# Altium schematic sheet Sheet5.SchDoc(Sheet5)
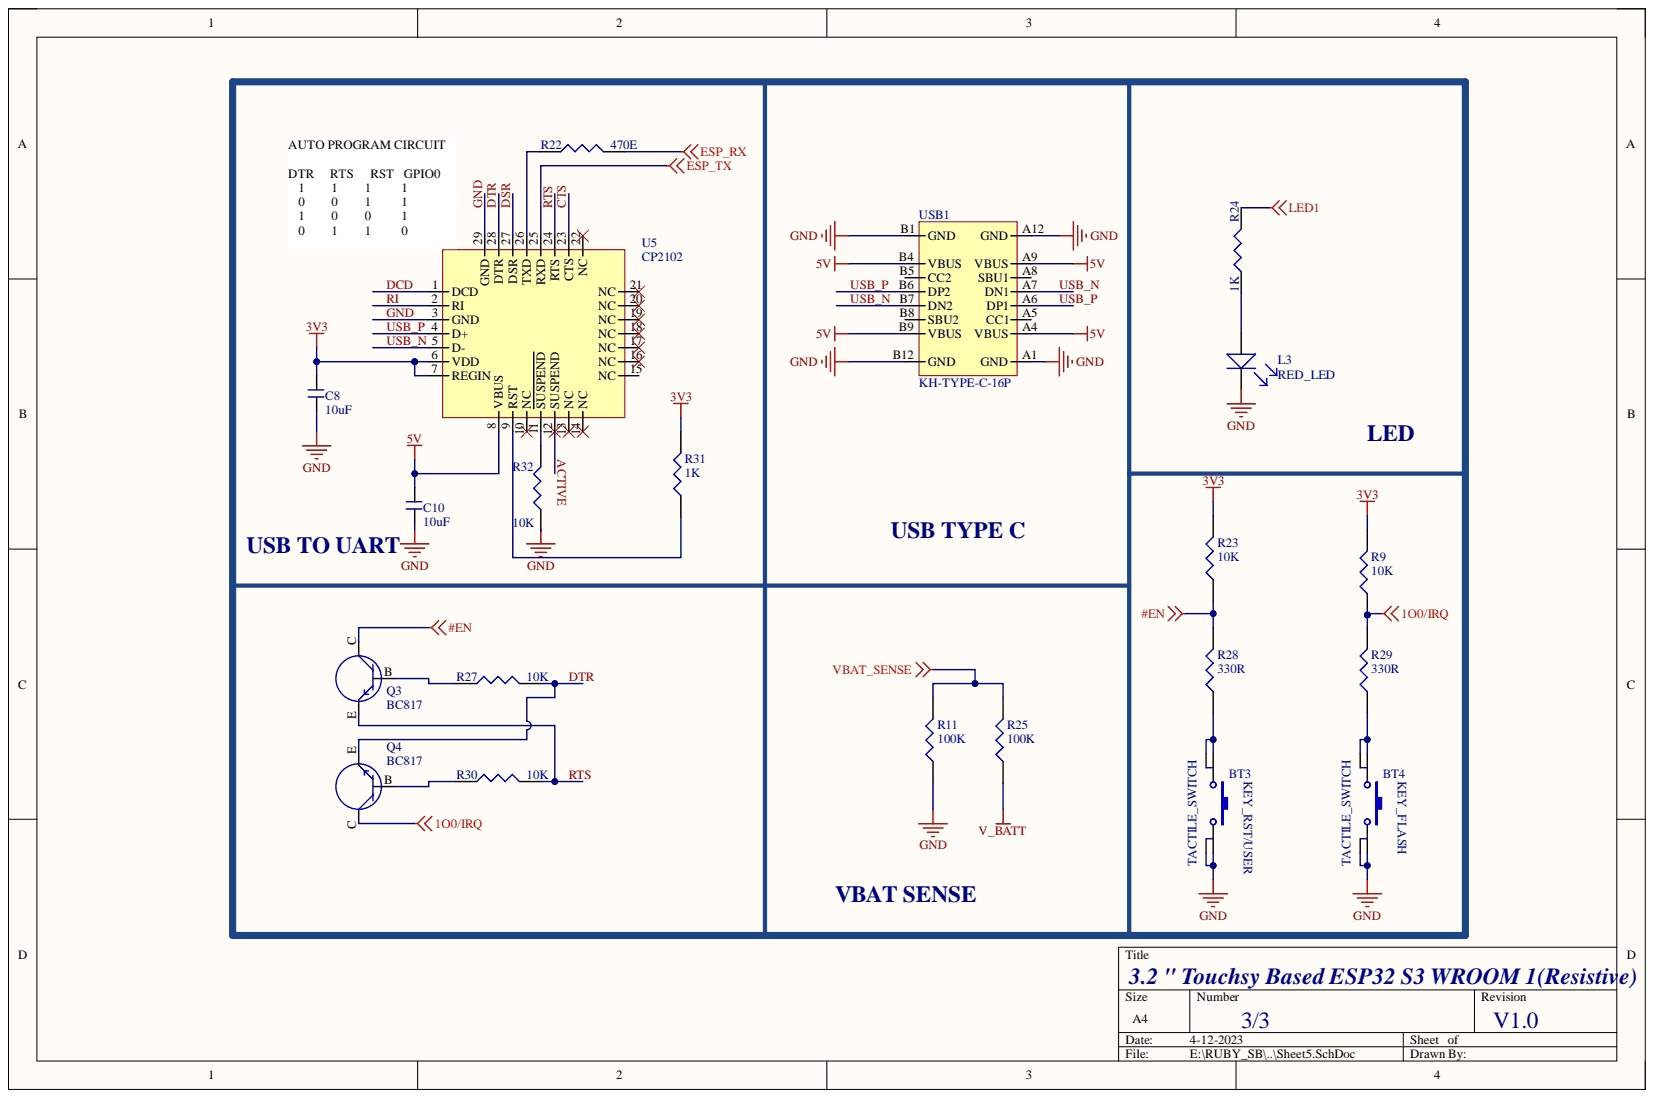
[diagram p0: Sheet5.SchDoc]

## Parts
BT3  TACTILE_SWITCH  [TACTILE]
BT4  TACTILE_SWITCH  [TACTILE]
C8  10uF  [C_0603]
C10  10uF  [C_0603]
L3  RED_LED  [LED_0603]
Q3  BC817  [SOT23]
Q4  BC817  [SOT23]
R9  10K  [R_0603]
R11  100K  [R_0603]
R22  470E  [R_0603]
R23  10K  [R_0603]
R24  1K  [R_0603]
R25  100K  [R_0603]
R27  10K  [R_0603]
R28  330R  [R_0603]
R29  330R  [R_0603]
R30  10K  [R_0603]
R31  1K  [R_0603]
R32  10K  [R_0603]
U5  CP2102  [CP2102]
USB1  KH-TYPE-C-16P  [USBTYPE-C-31-M-12]

## Nets
1O0/IRQ: Q4.C R9.1 R29.2
3V3: C8.1 R9.2 R23.2 R31.2 U5.6(VDD) U5.7(REGIN)
5V: C10.1 U5.8(VBUS) USB1.A4(VBUS) USB1.A9(VBUS) USB1.B4(VBUS) USB1.B9(VBUS)
#EN: Q3.C R23.1 R28.2
ESP_RX: R22.2
ESP_TX: U5.25(RXD)
LED1: R24.2
V_BATT: R25.1
VBAT_SENSE: R11.2 R25.2
ACTIVE: U5.12(SUSPEND)
CTS: U5.23(CTS)
DCD: U5.1(DCD)
DSR: U5.27(DSR)
DTR: Q4.E R27.2 U5.28(DTR)
GND: BT3.3 BT3.4 BT4.3 BT4.4 C8.2 C10.2 L3.K R11.1 R32.1 U5.3(GND) U5.29(GND) USB1.A1(GND) USB1.A12(GND) USB1.B1(GND) USB1.B12(GND)
RI: U5.2(RI)
RTS: Q3.E R30.2 U5.24(RTS)
USB_N: U5.5(D-) USB1.A7(DN1) USB1.B7(DN2)
USB_P: U5.4(D+) USB1.A6(DP1) USB1.B6(DP2)
NetBT3_1: BT3.1 BT3.2 R28.1
NetBT4_1: BT4.1 BT4.2 R29.1
NetL3_A: L3.A R24.1
NetQ3_B: Q3.B R27.1
NetQ4_B: Q4.B R30.1
NetR22_1: R22.1 U5.26(TXD)
NetR31_1: R31.1 U5.9(RST)
NetR32_2: R32.2 U5.11(SUSPEND)

Not connected: U5 10(NC) 13(NC) 14(NC) 15(NC) 16(NC) 17(NC) 18(NC) 19(NC) 20(NC) 21(NC) 22(NC); USB1 A5(CC1) A8(SBU1) B5(CC2) B8(SBU2)

## Library data of the parts
C8 C10 = CAP_NONPOLAR: Component Kind: Standard; Library Name: dxp_pasl_lib.IntLib
L3 = LED: Component Kind: Standard; Library Name: dxp_pasl_lib.IntLib
Q3 Q4 = TRANSISTER_NPN: Component Kind: Standard; Library Name: dxp_pasl_lib.IntLib
R9 R11 R22-R25 R27-R32 = RES: Component Kind: Standard; Library Name: dxp_pasl_lib.IntLib
U5 = CP2102_1: QFN, 28-Leads, Body 5.0x5.0mm (max), Pitch 0.50mm, IPC Medium Density; Component Kind: Standard; Library Name: dxp_pasl_lib.IntLib
USB1 = USB TYPE-C KH-TYPE-C-16P: 3A 1 Surface Mount 16 Female -40?~+85? Type-C SMD USB Connectors ROHS #  C709357; Component Kind: Standard; ComponentLink2Description: New link; ComponentLink2URL: https://lcsc.com/product-detail/USB-Connectors_Shenzhen-Kinghelm-Elec-KH-TYPE-C-16P_C709357.html; Supplier 1: LCSC; Supplier Part Number 1: C165948; Library Name: dxp_pasl_lib.IntLib
BT3 BT4 = TACTILE_SWITCH: Component Kind: Standard; Library Name: dxp_pasl_lib.IntLib
